# Altium schematic sheet USB_UART.SchDoc
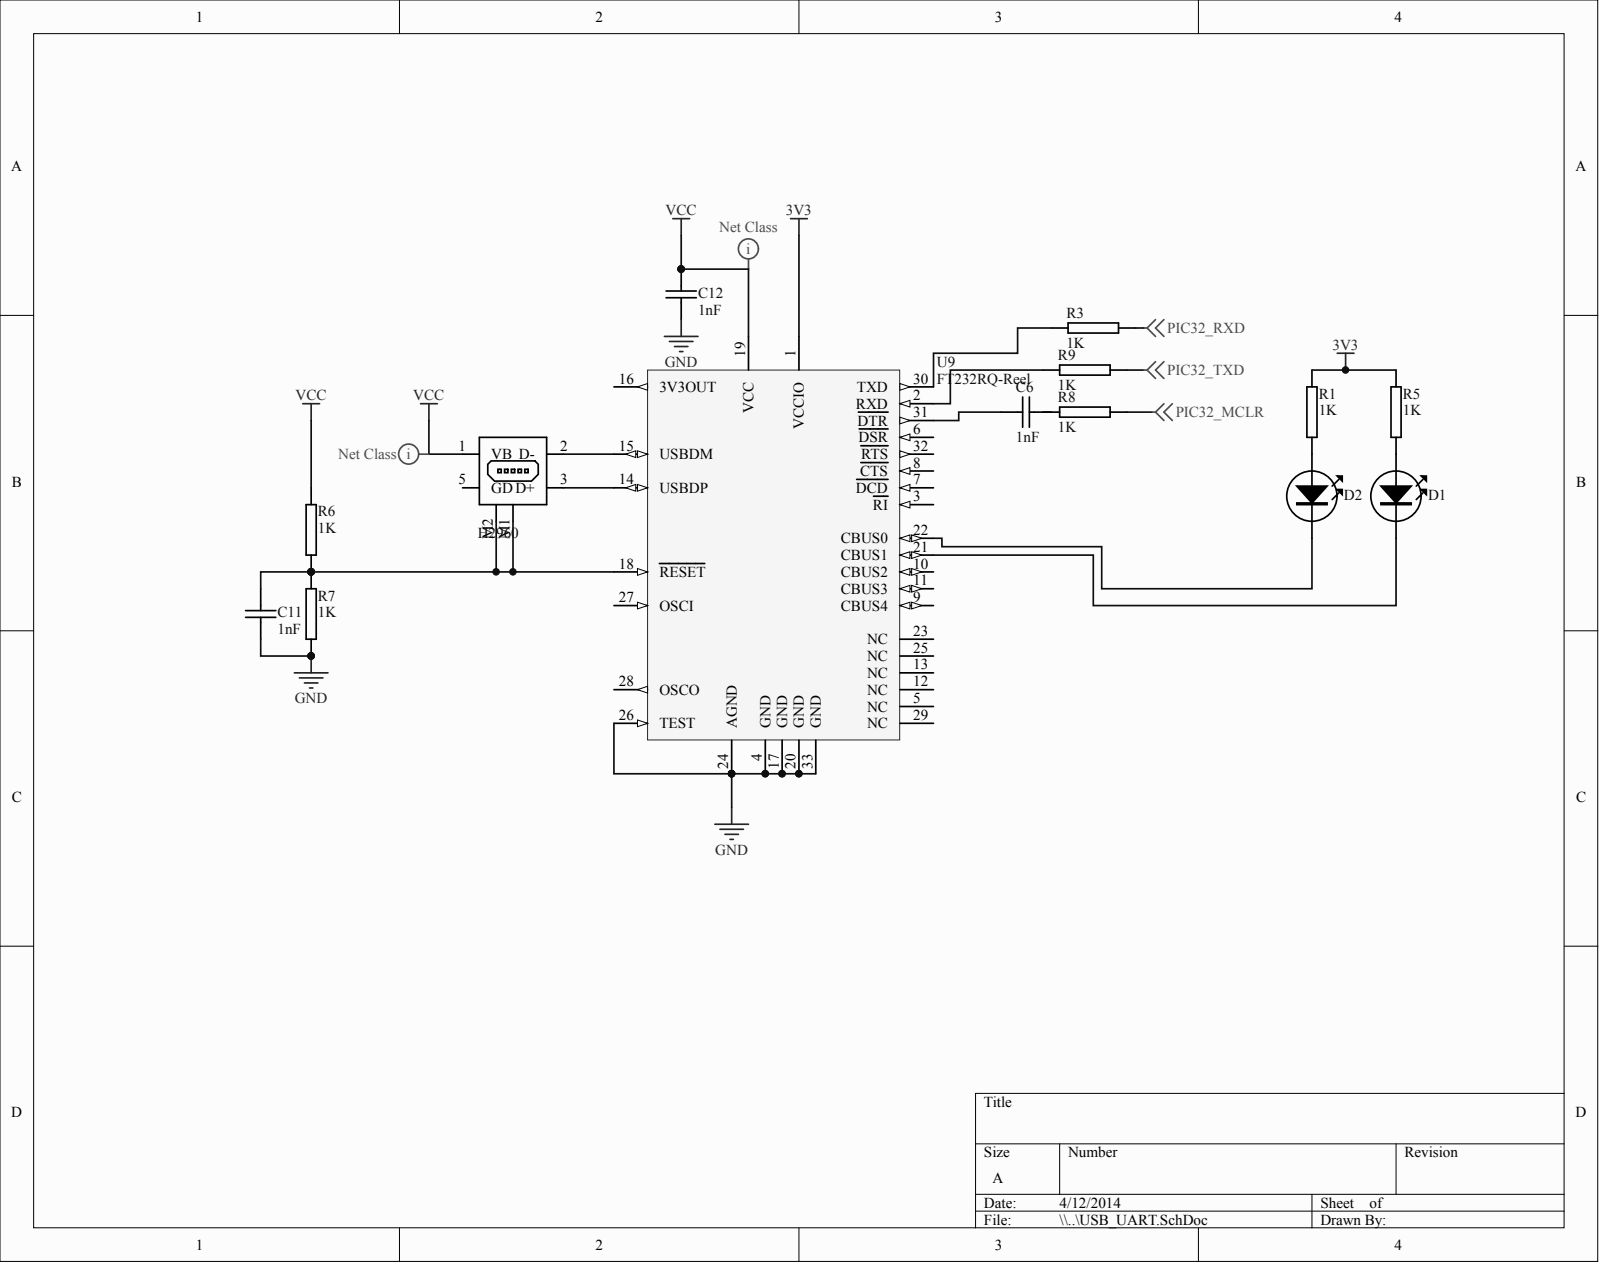
[diagram p0: USB_UART.SchDoc]

## Parts
C6  1nF
C11  1nF
C12  1nF
D1  TOPLED-RED  (value not drawn)
D2  TOPLED-RED  (value not drawn)
K2  H2960
R1  1K
R3  1K
R5  1K
R6  1K
R7  1K
R8  1K
R9  1K
U9  FT232RQ-Reel

## Nets
3V3: R1.2 R5.2 U9.1(VCCIO)
GND: C11.2 C12.2 R7.2 U9.4(GND) U9.17(GND) U9.20(GND) U9.24(AGND) U9.26(TEST) U9.33(GND)
PIC32_MCLR: R8.2
PIC32_RXD: R3.2
PIC32_TXD: R9.2
VCC: C12.1 K2.1(VB) R6.1 U9.19(VCC)
NetC6_1: C6.1 U9.31(DTR)
NetC11_1: C11.1 K2.M1 K2.M2(GD) R6.2 R7.1 U9.18(RESET)
NetD1_A: D1.A R5.1
NetD1_K: D1.K U9.21(CBUS1)
NetD2_A: D2.A R1.1
NetD2_K: D2.K U9.22(CBUS0)
NetK2_2: K2.2(D-) U9.15(USBDM)
NetK2_3: K2.3(D+) U9.14(USBDP)
NetR3_1: R3.1 U9.30(TXD)
NetR9_1: R9.1 U9.2(RXD)

Not connected: C6 2; K2 5; R8 1; U9 3(RI) 5(NC) 6(DSR) 7(DCD) 8(CTS) 9(CBUS4) 10(CBUS2) 11(CBUS3) 12(NC) 13(NC) 16(3V3OUT) 23(NC) 25(NC) 27(OSCI) 28(OSCO) 29(NC) 32(RTS)

## Library data of the parts
D1 D2 = (no symbol name): Manufacturer: OSRAM Opto Semiconductors Inc; Manufacturer Part Number: LS T676-Q1R2-1-Z; Reel: D13; Supplier 1: Digi-Key; Supplier Part Number 1: 475-1133-2-ND
R1 R3 R5-R9 = (no symbol name): Manufacturer Part Number: RMCF 1/16 1K 1% R; Manufacturer: Stackpole Electronics Inc; Reel: R14; Supplier 1: Digi-Key; Supplier Part Number 1: RMCF0603FT1K00TR-ND
C6 C11 C12 K2 U9 = (no symbol name)
  U9: Published: 19-Aug-2010; DatasheetVersion: ver 2.07, Jul-2010; PackageVersion: 2010; PackageReference: PQFN5X5-32; PackageDescription: 32-Pin Quad Flat No-Lead 0.5 mm Pitch, Pullback, 3.2 x 3.2 mm Exposed Pad; ComponentLink1Description: Manufacturer Link; ComponentLink1URL: http://www.ftdichip.com/Products/ICs/FT232R.htm; ComponentLink2Description: Datasheet; ComponentLink2URL: http://www.ftdichip.com/Support/Documents/DataSheets/ICs/DS_FT232R.pdf; Publisher: Altium Limited
